# Altium schematic sheet SDEC945-xB_Alimentations.SchDoc(SDEC945-xB_Alimentations)
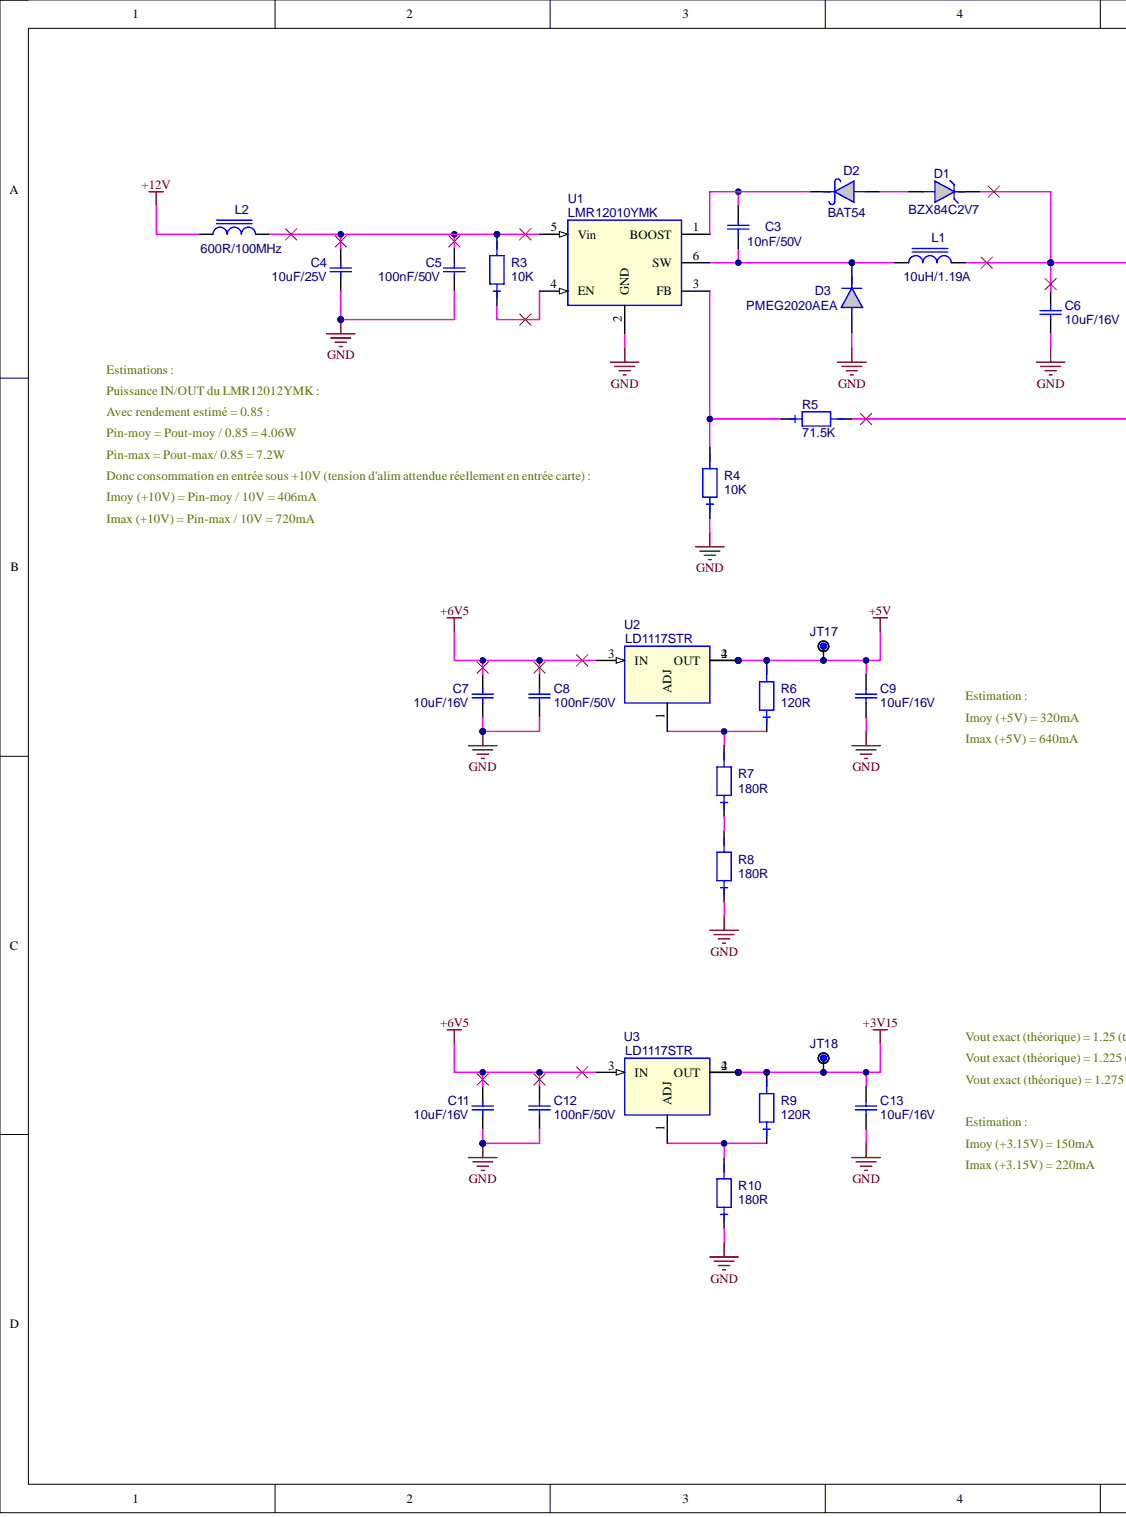
[diagram p0: SDEC945-xB_Alimentations.SchDoc, left]
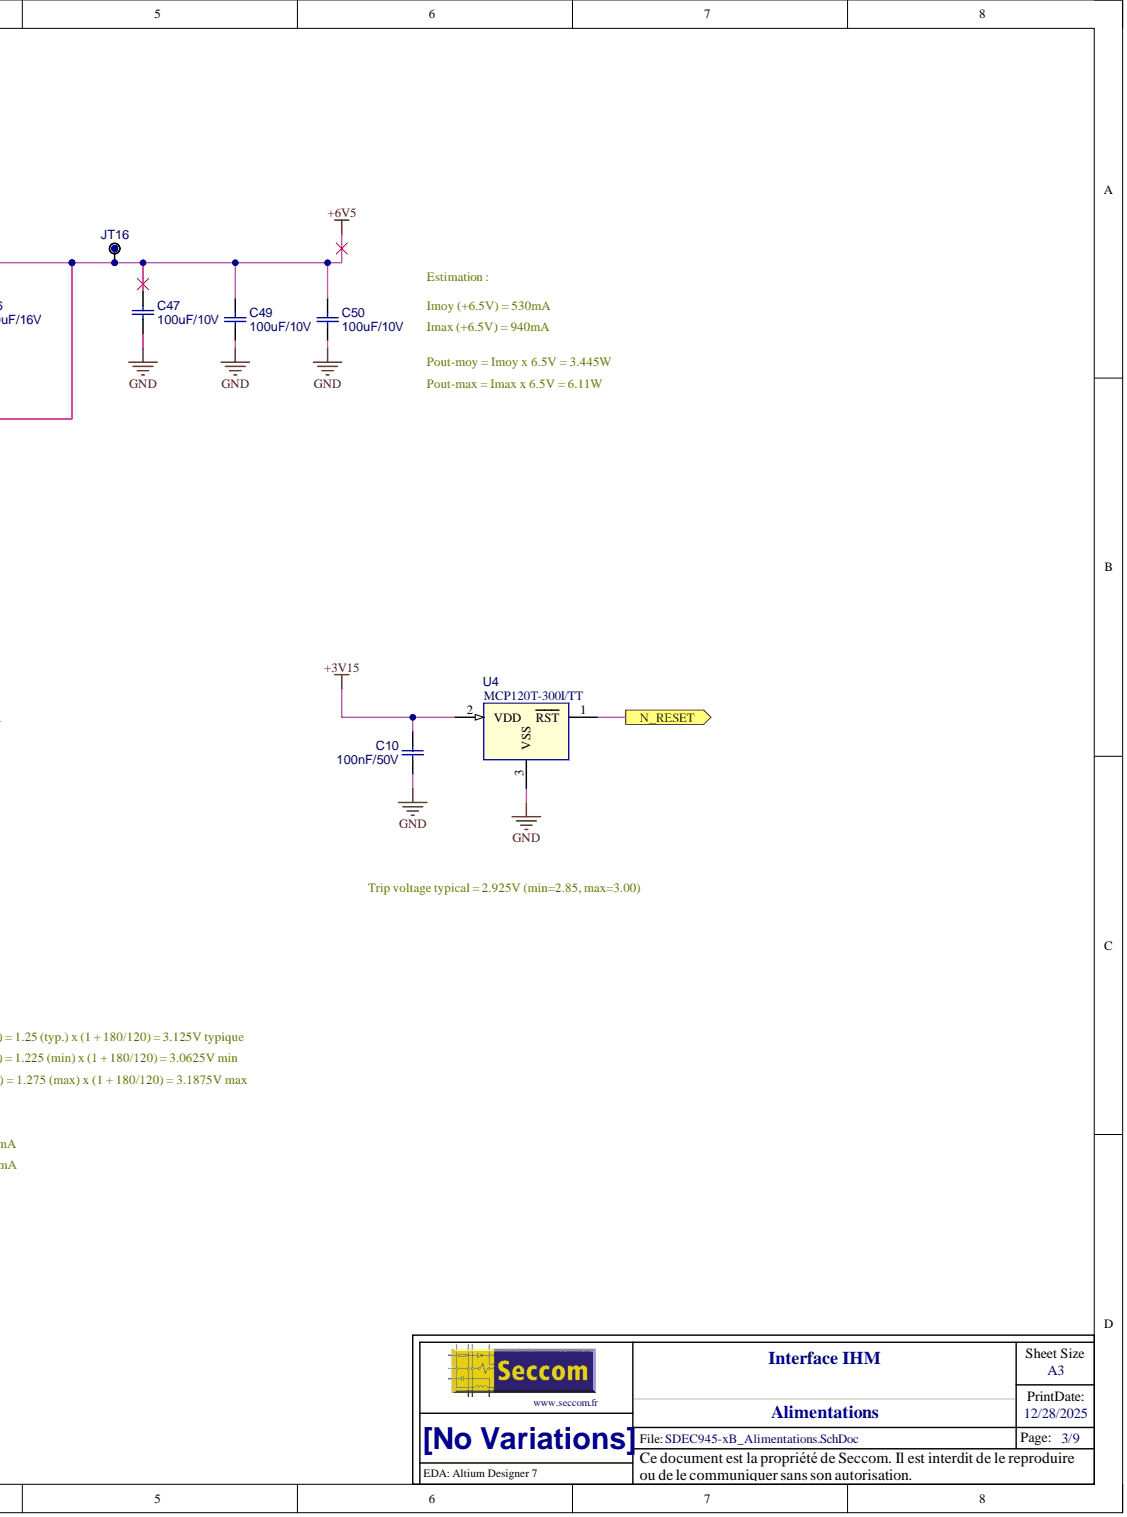
[diagram p1: SDEC945-xB_Alimentations.SchDoc, right]

## Parts
C3  value 10nF/50V  (hidden comment: C_0603_X7R_10%_10N-50V)
C4  value 10uF/25V  (hidden comment: C_0805_X5R_10%_10U-25V)
C5  value 100nF/50V  (hidden comment: C_0603_X7R_10%_100N-50V)
C6  value 10uF/16V  (hidden comment: C_0805_X5R_10%_10U-16V)
C7  value 10uF/16V  (hidden comment: C_0805_X5R_10%_10U-16V)
C8  value 100nF/50V  (hidden comment: C_0603_X7R_10%_100N-50V)
C9  value 10uF/16V  (hidden comment: C_0805_X5R_10%_10U-16V)
C10  value 100nF/50V  (hidden comment: C_0603_X7R_10%_100N-50V)
C11  value 10uF/16V  (hidden comment: C_0805_X5R_10%_10U-16V)
C12  value 100nF/50V  (hidden comment: C_0603_X7R_10%_100N-50V)
C13  value 10uF/16V  (hidden comment: C_0805_X5R_10%_10U-16V)
C47  value 100uF/10V  (hidden comment: C_1206_X5R_20%_100U-10V)
C49  value 100uF/10V  (hidden comment: C_1206_X5R_20%_100U-10V)
C50  value 100uF/10V  (hidden comment: C_1206_X5R_20%_100U-10V)
D1  BZX84C2V7
D2  BAT54
D3  PMEG2020AEA
JT16  POINT_TEST_PAD_40MIL  (value not drawn)  (no BOM)
JT17  POINT_TEST_PAD_40MIL  (value not drawn)  (no BOM)
JT18  POINT_TEST_PAD_40MIL  (value not drawn)  (no BOM)
L1  value 10uH/1.19A  (hidden comment: SELF_10UH_1.19A_744043100)
L2  value 600R/100MHz  (hidden comment: IND_FERRITE_1206_74279218)
R3  value 10K  (hidden comment: RES_0603_1%_100PPM_10K)
R4  value 10K  (hidden comment: RES_0603_1%_100PPM_10K)
R5  value 71.5K  (hidden comment: RES_0603_1%_100PPM_71.5K)
R6  value 120R  (hidden comment: RES_0603_1%_100PPM_120R)
R7  value 180R  (hidden comment: RES_0603_1%_100PPM_180R)
R8  value 180R  (hidden comment: RES_0603_1%_100PPM_180R)
R9  value 120R  (hidden comment: RES_0603_1%_100PPM_120R)
R10  value 180R  (hidden comment: RES_0603_1%_100PPM_180R)
U1  LMR12010YMK
U2  LD1117STR
U3  LD1117STR
U4  MCP120T-300I/TT

## Ports
N_RESET

## Nets
+3V15: C10.1 C13.2 JT18.1 R9.2 U3.2(OUT) U3.4 U4.2
+5V: C9.2 JT17.1 R6.2 U2.2(OUT) U2.4
+6V5: C6.2 C7.2 C8.1 C11.2 C12.1 C47.2 C49.2 C50.2 D1.3 JT16.1 L1.2 R5.2 U2.3(IN) U3.3(IN)
+12V: L2.1
GND: C4.1 C5.2 C6.1 C7.1 C8.2 C9.1 C10.2 C11.1 C12.2 C13.1 C47.1 C49.1 C50.1 D3.A R4.1 R8.1 R10.1 U1.2(GND) U4.3
N_RESET: U4.1 ; port N_RESET
NetC3_1: C3.1 D3.K L1.1 U1.6(SW)
NetC3_2: C3.2 D2.3 U1.1(BOOST)
NetC4_2: C4.2 C5.1 L2.2 R3.2 U1.5(Vin)
NetD1_1: D1.1 D2.1
NetR3_1: R3.1 U1.4(EN)
NetR4_2: R4.2 R5.1 U1.3(FB)
NetR6_1: R6.1 R7.2 U2.1(ADJ)
NetR7_1: R7.1 R8.2
NetR9_1: R9.1 R10.2 U3.1(ADJ)

## Library data of the parts
C3 = C_0603_X7R_10%_10N-50V: Condensateur céramique, X7R, 10%, CMS 0603, 10nF/50V; Boitier: 0603; Coeff: 1; Creation: FB (01/03/12); Fabricant: Kemet; Ref Fab: C0603C103K5RAC; Remarque: {Remarque}; Component Kind: Standard; ComponentLink1Description: Datasheet; ComponentLink2Description: Datasheet Boitier; Library Name: SC94x.SchLib; Supplier 1: Farnell; Supplier 2: RadioSpares; Supplier Part Number 1: 1414609; Supplier Part Number 2: 2644595; ComponentLink1URL: O:\_Documentation\Datasheets\Kemet\Condos_Ceramic_CMS.pdf; ComponentLink2URL: o:\_Documentation\Datasheets\_BOITIERS\PASSIF_CMS\
C4 = C_0805_X5R_10%_10U-25V: Condensateur céramique, X5R, 10%, CMS 0805, 10uF/25V; Boitier: 0805; Coeff: 1; Creation: FB (30/07/13); Fabricant: Murata; Ref Fab: GRM21BR61E106KA73L; Remarque: {Remarque}; Component Kind: Standard; ComponentLink1Description: Datasheet; ComponentLink2Description: Datasheet Boitier; Library Name: SC94x.SchLib; Supplier 1: Farnell; Supplier 2: Mouser; Supplier Part Number 1: 1735530; Supplier Part Number 2: 81-GRM21BR61E106KA3L; ComponentLink1URL: O:\_Documentation\Datasheets\Murata\Condensateur_GRM21BR61E106KA73.pdf; ComponentLink2URL: o:\_Documentation\Datasheets\_Boitiers\PASSIF_CMS\RC_0805_(2x1,25)_(Schneider).pdf
C5 C8 C10 C12 = C_0603_X7R_10%_100N-50V: Condensateur céramique, X7R, 10%, CMS 0603, 100nF/50V; Boitier: 0603; Coeff: 1; Creation: FB (29/02/12); Fabricant: Kemet; Ref Fab: C0603C104K5RAC; Remarque: {Remarque}; Component Kind: Standard; ComponentLink1Description: Datasheet; ComponentLink2Description: Datasheet Boitier; Library Name: SC94x.SchLib; Supplier 1: Farnell; Supplier Part Number 1: 1288255; ComponentLink1URL: O:\_Documentation\Datasheets\Kemet\Condos_Ceramic_CMS.pdf; ComponentLink2URL: o:\_Documentation\Datasheets\_BOITIERS\PASSIF_CMS\
C6 C7 C9 C11 C13 = C_0805_X5R_10%_10U-16V: Condensateur céramique, X5R, 10%, CMS 0805, 10uF/16V; Boitier: 0805; Coeff: 1; Creation: FB (02/10/12); Fabricant: Kemet; Ref Fab: C0805C106K4PAC; Remarque: {Remarque}; Component Kind: Standard; ComponentLink1Description: Datasheet; ComponentLink2Description: Datasheet Boitier; Library Name: SC94x.SchLib; Supplier 1: Farnell; Supplier 2: Mouser; Supplier Part Number 1: 1288204; Supplier Part Number 2: 80-C0805C106K4P; ComponentLink1URL: O:\_Documentation\Datasheets\Kemet\Condos_Ceramic_CMS.pdf; ComponentLink2URL: o:\_Documentation\Datasheets\_Boitiers\PASSIF_CMS\RC_0805_(2x1,25)_(Schneider).pdf
C47 C49 C50 = C_1206_X5R_20%_100U-10V: Condensateur céramique, X5R, 20%, CMS 1206, 100uF/10V; Boitier: 1206; Coeff: 1; Creation: ZA (13/09/13); Fabricant: TDK; Ref Fab: C3216X5R1A107M160AC; Remarque: {Remarque}; Component Kind: Standard; ComponentLink1Description: Datasheet; ComponentLink2Description: Datasheet Boitier; Library Name: SC94x.SchLib; Supplier 1: Farnell; Supplier 2: Mouser; Supplier Part Number 1: 2211193; Supplier Part Number 2: 810-C3216X5R1A107M; ComponentLink1URL: O:\_Documentation\Datasheets\TDK\general_B11.pdf; ComponentLink2URL: o:\_Documentation\Datasheets\TDK\Capacitors_MLCC_cseries.pdf
D1 = BZX84C2V7: Diode Zener, série BZX84C, 0.3W, +/-5%, 2.7V, CMS; Boitier: SOT23_3L; Coeff: 1; Creation: PDP (10/07/12); Fabricant: NXP; Remarque: {Remarque}; Verification: FB (07/09/12); Component Kind: Standard; ComponentLink1Description: Datasheet; ComponentLink2Description: Datasheet Boitier; Library Name: SC94x.SchLib; Ref Fab: BZX84C2V7; Supplier 1: Farnell; Supplier 2: Mouser; Supplier Part Number 1: 1081415; Supplier Part Number 2: 771-BZX84-C2V7-T/R; Value: BZX84C2V7; ComponentLink1URL: o:\_Documentation\Datasheets\NXP\BZX84_SERIES.pdf; ComponentLink2URL: o:\_Documentation\Datasheets\_BOITIERS\ACTIF_CMS\SOT\SOT_23_3P_(Schneider).PDF
D2 = BAT54: Diode Schottky, Vrrm=30V, If=200mA, Vf=0.8V, CMS; Boitier: SOT23_3L; Coeff: 1; Creation: LJ (FT51101); Fabricant: NXP; Reference: 000598/00; Remarque: {Remarque}; Verification: LJ (15/11/01); Component Kind: Standard; ComponentLink1Description: Datasheet; ComponentLink2Description: Datasheet Boitier; Library Name: DIODES.SchLib; Ref Fab: BAT54; Supplier 1: Farnell; Supplier Part Number 1: 1081190; Value: BAT54; ComponentLink1URL: O:\_Documentation\Datasheets\NXP\BAT54_series.pdf; ComponentLink2URL: o:\_Documentation\Datasheets\_BOITIERS\ACTIF_CMS\SOT\SOT_23_3P_(Schneider).PDF
D3 = PMEG2020AEA: Diode Schottky, Vrrm=20V, If=2A, Vf=0.45V, CMS; Boitier: SOD323; Coeff: 1; Creation: PDP (10/07/12); Fabricant: NXP; Remarque: {Remarque}; Component Kind: Standard; ComponentLink1Description: Datasheet; ComponentLink2Description: Datasheet Boitier; Library Name: SC94x.SchLib; Ref Fab: PMEG2020AEA; Value: PMEG2020AEA; ComponentLink1URL: o:\_Documentation\Datasheets\NXP\PMEG2020AEA.PDF; ComponentLink2URL: o:\_Documentation\Datasheets\_BOITIERS\ACTIF_CMS\SOD\SOD_80_(MLL34)_(Schneider).PDF
JT16-JT18 = POINT_TEST_PAD_40MIL: Point de test sur CI, pad de diamètre 1.016mm (40mils); Coeff: 1; Creation: FB (04/10/12); Remarque: {Remarque}; ComponentLink1Description: Datasheet; ComponentLink2Description: Datasheet Boitier; Value: POINT_TEST; Library Name: CONN_PICOT.SchLib
L1 = SELF_10UH_1.19A_744043100: Inductance bobinée CMS, 10uH +/- 10%, I=1.19A, Rdc=0.095R, SRF=40MHz, -40/+85°C; Boitier: 4.8x4.8_h2.8mm; Coeff: 1; Creation: LJ (02/08/12); Fabricant: Wurth Elektronik; Component Kind: Standard; ComponentLink1Description: Datasheet; ComponentLink2Description: Datasheet Boitier; Ref Fab: 744043100; ComponentLink1URL: O:\_Documentation\Datasheets\Wurth_Elektronik\744043100.pdf; ComponentLink2URL: O:\_Documentation\Datasheets\Wurth_Elektronik\744043100.pdf; Library Name: INDUCTIF.SchLib
L2 = IND_FERRITE_1206_74279218: Inductance à ferrite multicouches CMS, imp=600R@100MHz, +/-25%, Rdc=100mR, I=2A; Boitier: 1206; Coeff: 1; Creation: LJ (12/08/08); Fabricant: Wurth Elektronik; Reference: 000049/00; Remarque: Alternative aux selfs de choc; Component Kind: Standard; ComponentLink1Description: Datasheet; ComponentLink2Description: Datasheet Boitier; Ref Fab: 74279218; ComponentLink1URL: o:\_Documentation\Datasheets\Wurth_Elektronik\74279218.pdf; ComponentLink2URL: o:\_Documentation\Datasheets\_Boitiers\PASSIF_CMS\RC_1206_(3,2x1,6)_(Schneider).pdf; Library Name: INDUCTIF.SchLib
R3 R4 = RES_0603_1%_100PPM_10K: Résistance, 0.100W, 1%, 100ppm, 75V, 10K; Boitier: 0603; Coeff: 1; Creation: JPL (05/07/12); Fabricant: Vishay; Ref Fab: CRCW060310K0FKEA; Remarque: {Remarque}; Component Kind: Standard; ComponentLink1Description: Datasheet; ComponentLink2Description: Datasheet Boitier; Library Name: SC94x.SchLib; Supplier 1: Farnell; Supplier 2: RadioSpares; Supplier Part Number 1: 1469748; Supplier Part Number 2: 6789667; ComponentLink1URL: O:\_Documentation\Datasheets\Vishay\Resistances_D-CRCWe3.pdf; ComponentLink2URL: o:\_Documentation\Datasheets\_BOITIERS\PASSIF_CMS\
R5 = RES_0603_1%_100PPM_71.5K: Résistance, 0.100W, 1%, 100ppm, 75V, 71.5K; Boitier: 0603; Coeff: 1; Creation: FB (07/09/12); Fabricant: Vishay; Ref Fab: CRCW060371K5FKEA; Remarque: {Remarque}; Component Kind: Standard; ComponentLink1Description: Datasheet; ComponentLink2Description: Datasheet Boitier; Library Name: SC94x.SchLib; Supplier 1: Farnell; Supplier 2: Mouser; Supplier Part Number 1: 1469837; Supplier Part Number 2: 71-CRCW0603-71.5K-E3; ComponentLink1URL: O:\_Documentation\Datasheets\Vishay\Resistances_D-CRCWe3.pdf; ComponentLink2URL: o:\_Documentation\Datasheets\_BOITIERS\PASSIF_CMS\
R6 R9 = RES_0603_1%_100PPM_120R: Résistance, 0.100W, 1%, 100ppm, 75V, 120R; Boitier: 0603; Coeff: 1; Creation: FB (07/09/12); Fabricant: Vishay; Ref Fab: CRCW0603120RFKEA; Remarque: {Remarque}; Component Kind: Standard; ComponentLink1Description: Datasheet; ComponentLink2Description: Datasheet Boitier; Library Name: SC94x.SchLib; Supplier 1: Farnell; Supplier 2: Mouser; Supplier Part Number 1: 1652832; Supplier Part Number 2: 71-CRCW0603-120-E3; ComponentLink1URL: O:\_Documentation\Datasheets\Vishay\Resistances_D-CRCWe3.pdf; ComponentLink2URL: o:\_Documentation\Datasheets\_BOITIERS\PASSIF_CMS\
R7 R8 R10 = RES_0603_1%_100PPM_180R: Résistance, 0.100W, 1%, 100ppm, 75V, 180R; Boitier: 0603; Coeff: 1; Creation: FB (06/09/12); Fabricant: Vishay; Ref Fab: CRCW0603180RFKEA; Remarque: {Remarque}; Component Kind: Standard; ComponentLink1Description: Datasheet; ComponentLink2Description: Datasheet Boitier; Library Name: SC94x.SchLib; Supplier 1: Farnell; Supplier 2: Mouser; Supplier Part Number 1: 1469762; Supplier Part Number 2: 71-CRCW0603-180-E3; ComponentLink1URL: O:\_Documentation\Datasheets\Vishay\Resistances_D-CRCWe3.pdf; ComponentLink2URL: o:\_Documentation\Datasheets\_BOITIERS\PASSIF_CMS\
U1 = LMR12010YMK: Régulateur ajustable step down, 0.8~20V, 1A, Vin=3~20V, -40/+125°C, CMS; Boitier: TSOT-6; Coeff: 1; Creation: PDP (10/07/12); Fabricant: National Semiconductor; Remarque: {Remarque}; Verification: LJ (02/08/12); Component Kind: Standard; ComponentLink1Description: Datasheet; ComponentLink2Description: Datasheet Boitier; Ref Fab: LMR12010YMK; Supplier 1: Farnell; Supplier 2: Mouser; Supplier Part Number 1: 2060645; Supplier Part Number 2: 926-LMR12010YMKENOPB; Value: LMR12010YMK; ComponentLink1URL: O:\_Documentation\Datasheets\National_SemiConductors\LMR12010.pdf; ComponentLink2URL: o:\_Documentation\Datasheets\_Boitiers\ACTIF_CMS\; Library Name: CI_REGULAT.SchLib
U2 U3 = LD1117STR: Régulateur de tension ajustable positif, 1.25~15V, 0.8A, LDO (1V), Vin=15Vmax, CMS; Boitier: SOT223; Coeff: 1; Creation: FB (07/09/12); Fabricant: ST; Remarque: {Remarque}; Component Kind: Standard; ComponentLink1Description: Datasheet; ComponentLink2Description: Datasheet Boitier; Library Name: SC94x.SchLib; Ref Fab: LD1117STR; Value: LD1117STR; ComponentLink1URL: o:\_Documentation\Datasheets\ST\LD1117.pdf; ComponentLink2URL: o:\_Documentation\Datasheets\_Boitiers\ACTIF_CMS\SOT & TSOT\SOT223_4_(Schneider).pdf
U4 = MCP120T-300I/TT: Microcontroller Supervisory Circuit with Open Drain Output, Active Low, 2.925V trip point; Boitier: SOT23_3L; Coeff: 1; Creation: PDP (10/07/2012); Fabricant: Microchip; Remarque: {Remarque}; Verification: FB (11/09/12); Component Kind: Standard; ComponentLink1Description: Datasheet; ComponentLink2Description: Datasheet Boitier; Library Name: SC94x.SchLib; Ref Fab: MCP120T-300I/TT; Supplier 1: Farnell; Supplier 2: Mouser; Supplier Part Number 1: 1292233; Supplier Part Number 2: 579-MCP120T-300I/TT; Value: MCP120T-300I/TT; ComponentLink1URL: o:\_Documentation\Datasheets\MicroChip\MCP120.pdf; ComponentLink2URL: o:\_Documentation\Datasheets\_Boitiers\ACTIF_CMS\SOT & TSOT\SOT23_3_(Schneider).pdf
